# Altium schematic sheet CLOCK.SchDoc
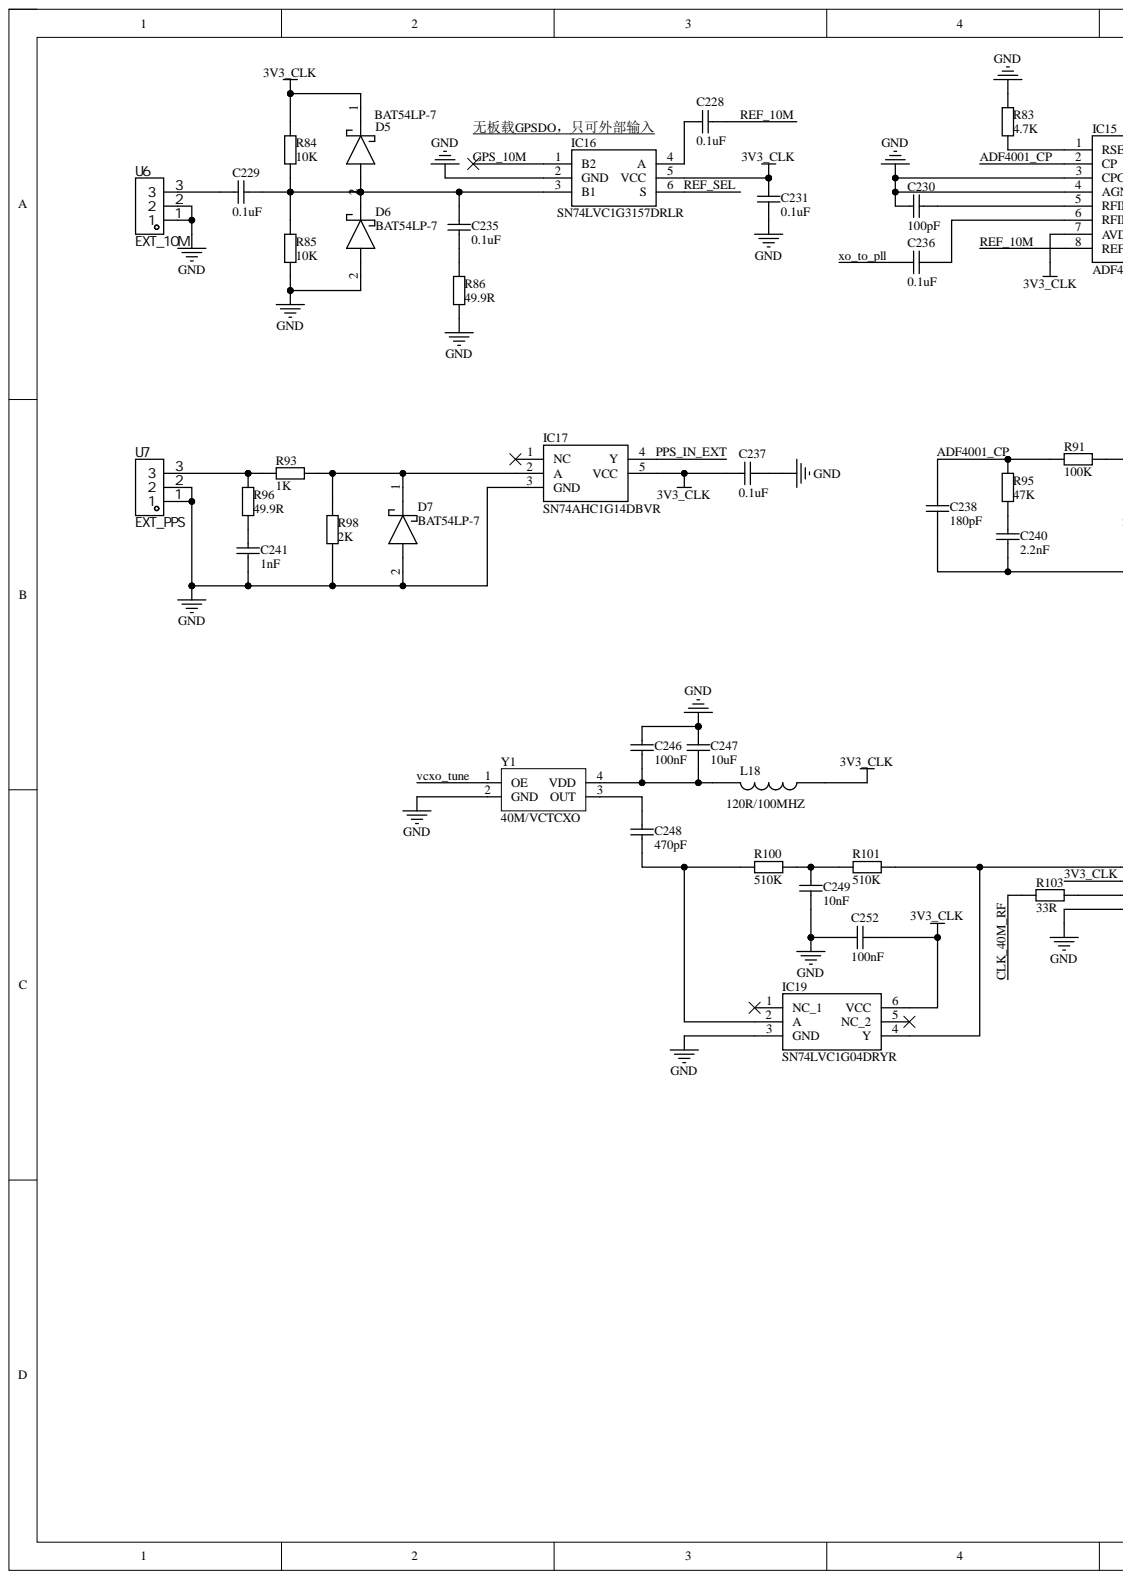
[diagram p0: CLOCK.SchDoc, left]
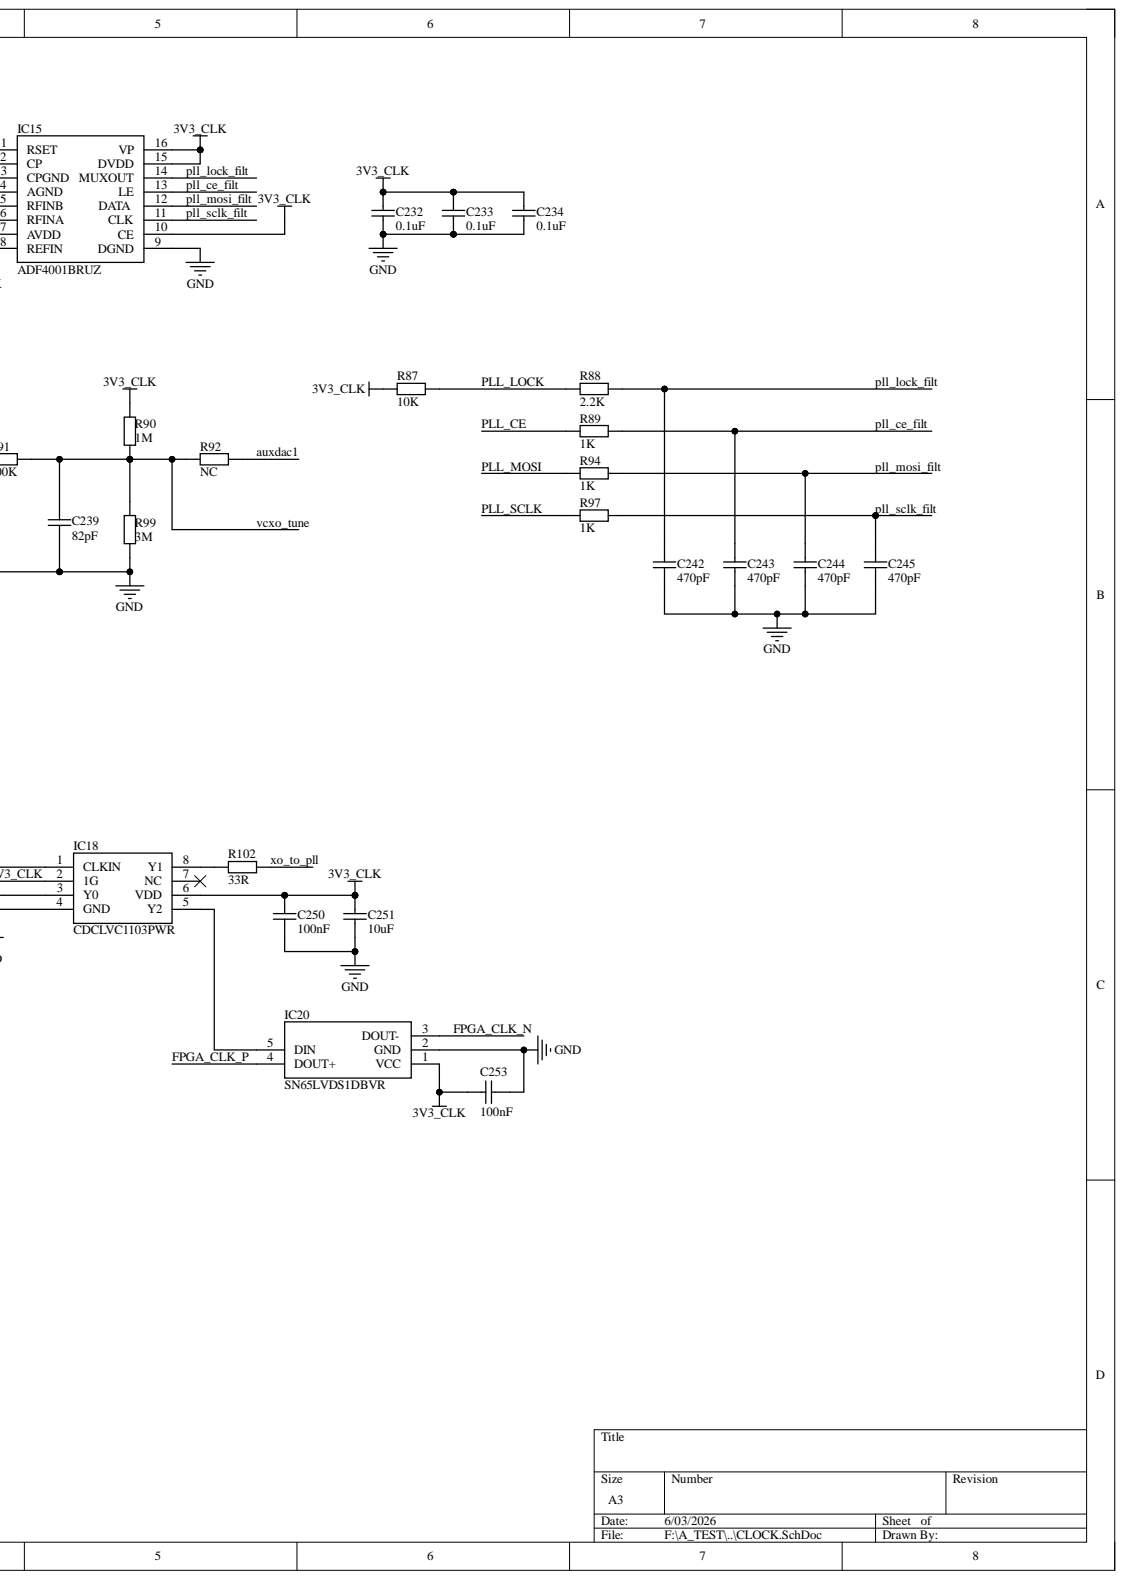
[diagram p1: CLOCK.SchDoc, right]

## Parts
C228  0.1uF  [C 0402_L]
C229  0.1uF  [C 0402_L]
C230  100pF  [C 0402_L]
C231  0.1uF  [C 0402_L]
C232  0.1uF  [C 0402_L]
C233  0.1uF  [C 0402_L]
C234  0.1uF  [C 0402_L]
C235  0.1uF  [C 0402_L]
C236  0.1uF  [C 0402_L]
C237  0.1uF  [C 0402_L]
C238  180pF  [C 0402_L]
C239  82pF  [C 0402_L]
C240  2.2nF  [C 0402_L]
C241  1nF  [C 0402_L]
C242  470pF  [C 0402_L]
C243  470pF  [C 0402_L]
C244  470pF  [C 0402_L]
C245  470pF  [C 0402_L]
C246  100nF  [C 0402_L]
C247  10uF  [C 0603_L]
C248  470pF  [C 0402_L]
C249  10nF  [C 0402_L]
C250  100nF  [C 0402_L]
C251  10uF  [C 0603_L]
C252  100nF  [C 0402_L]
C253  100nF  [C 0402_L]
D5  BAT54LP-7  [DESD3V3S1BL7B]
D6  BAT54LP-7  [DESD3V3S1BL7B]
D7  BAT54LP-7  [DESD3V3S1BL7B]
IC15  ADF4001BRUZ  [SOP65P640X120-16N]
IC16  SN74LVC1G3157DRLR  [SOTFL50P160X60-6N]
IC17  SN74AHC1G14DBVR  [SOT95P280X145-5N]
IC18  CDCLVC1103PWR  [SOP65P640X120-8N]
IC19  SN74LVC1G04DRYR  [TPS3420DDRYR]
IC20  SN65LVDS1DBVR  [SOT95P280X145-5N]
L18  120R/100MHZ  [L 0402]
R83  4.7K  [R 0402_L]
R84  10K  [R 0402_L]
R85  10K  [R 0402_L]
R86  49.9R  [R 0402_L]
R87  10K  [R 0402_L]
R88  2.2K  [R 0402_L]
R89  1K  [R 0402_L]
R90  1M  [R 0402_L]
R91  100K  [R 0402_L]
R92  NC  [R 0402_L]
R93  1K  [R 0402_L]
R94  1K  [R 0402_L]
R95  47K  [R 0402_L]
R96  49.9R  [R 0402_L]
R97  1K  [R 0402_L]
R98  2K  [R 0402_L]
R99  3M  [R 0402_L]
R100  510K  [R 0402_L]
R101  510K  [R 0402_L]
R102  33R  [R 0402_L]
R103  33R  [R 0402_L]
U6  EXT_10M  [SMA-SMD_73415-0963]
U7  EXT_PPS  [SMA-SMD_73415-0963]
Y1  40M/VCTCXO  [OSC 3225-4P]

## Nets
GND: C230.2 C231.1 C232.2 C233.2 C234.2 C237.2 C238.1 C239.2 C240.1 C241.1 C242.2 C243.2 C244.2 C245.2 C246.2 C247.2 C249.2 C250.1 C251.1 C252.1 C253.1 D6.2 D7.2 IC15.3(CPGND) IC15.4(AGND) IC15.9(DGND) IC16.2(GND) IC17.3(GND) IC18.4(GND) IC19.3(GND) IC20.2(GND) R83.1 R85.1 R86.1 R98.2 R99.2 U6.1 U6.2 U7.1 U7.2 Y1.2(GND)
3V3_CLK: C231.2 C232.1 C233.1 C234.1 C237.1 C250.2 C251.2 C252.2 C253.2 D5.1 IC15.7(AVDD) IC15.10(CE) IC15.15(DVDD) IC15.16(VP) IC16.5(VCC) IC17.5(VCC) IC18.2(1G) IC18.6(VDD) IC19.6(VCC) IC20.1(VCC) L18.1 R84.2 R87.1 R90.1
ADF4001_CP: C238.2 IC15.2(CP) R91.1 R95.1
auxdac1: R92.2
CLK_40M_RF: R103.2
FPGA_CLK_N: IC20.3(DOUT-)
FPGA_CLK_P: IC20.4(DOUT+)
GPS_10M: IC16.1(B2)
PLL_CE: R89.2
pll_ce_filt: C243.1 IC15.13(LE) R89.1
PLL_LOCK: R87.2 R88.2
pll_lock_filt: C242.1 IC15.14(MUXOUT) R88.1
PLL_MOSI: R94.2
pll_mosi_filt: C244.1 IC15.12(DATA) R94.1
PLL_SCLK: R97.2
pll_sclk_filt: C245.1 IC15.11(CLK) R97.1
PPS_IN_EXT: IC17.4(Y)
REF_10M: C228.1 IC15.8(REFIN)
REF_SEL: IC16.6(S)
vcxo_tune: C239.1 R90.2 R91.2 R92.1 R99.1 Y1.1(OE)
xo_to_pll: C236.2 R102.2
NetC228_2: C228.2 IC16.4(A)
NetC229_1: C229.1 U6.3
NetC229_2: C229.2 C235.1 D5.2 D6.1 IC16.3(B1) R84.1 R85.2
NetC230_1: C230.1 IC15.5(RFINB)
NetC235_2: C235.2 R86.2
NetC236_1: C236.1 IC15.6(RFINA)
NetC240_2: C240.2 R95.2
NetC241_2: C241.2 R96.1
NetC246_1: C246.1 C247.1 L18.2 Y1.4(VDD)
NetC248_1: C248.1 Y1.3(OUT)
NetC248_2: C248.2 IC19.2(A) R100.1
NetC249_1: C249.1 R100.2 R101.1
NetD7_1: D7.1 IC17.2(A) R93.1 R98.1
NetIC15_1: IC15.1(RSET) R83.2
NetIC18_1: IC18.1(CLKIN) IC19.4(Y) R101.2
NetIC18_3: IC18.3(Y0) R103.1
NetIC18_5: IC18.5(Y2) IC20.5(DIN)
NetIC18_8: IC18.8(Y1) R102.1
NetR93_2: R93.2 R96.2 U7.3

Not connected: IC17 1(NC); IC18 7(NC); IC19 1(NC_1) 5(NC_2)

## Library data of the parts
C228-C253 = Cap: 贴片电容; Component Kind: Standard; Library Name: A_Schlib.SchLib
  C228 C229 C231-C237: value: 0.1uF
  C230: value: 100pF
  C238: value: 180pF
  C239: value: 82pF
  C240: value: 2.2nF
  C241: value: 1nF
  C242-C245 C248: value: 470pF
  C246 C250 C252 C253: value: 100nF
  C247 C251: value: 10uF
  C249: value: 10nF
D5-D7 = BAT54LP-7: Schottky Diode; Manufacturer_Name: Diodes Incorporated; Arrow Part Number: BAT54LP-7; Arrow Price/Stock: https://www.arrow.com/en/products/bat54lp-7/diodes-incorporated?utm_currency=USD&region=nac; Component Kind: Standard; Datasheet Link: https://www.diodes.com//assets/Datasheets/BAT54LP.pdf; Library Name: SamacSys.SchLib; Manufacturer_Part_Number: BAT54LP-7; Mouser Part Number: 621-BAT54LP-7; Mouser Price/Stock: https://www.mouser.co.uk/ProductDetail/Diodes-Incorporated/BAT54LP-7?qs=rGAXPo9uwV29av2jO%2FVbTg%3D%3D
IC15 = ADF4001BRUZ: Integrated Circuit; Manufacturer_Name: Analog Devices; Arrow Part Number: ADF4001BRUZ; Arrow Price/Stock: https://www.arrow.com/en/products/adf4001bruz/maxim-integrated?region=nac; Component Kind: Standard; Datasheet Link: http://www.analog.com/static/imported-files/data_sheets/ADF4001.pdf; Height: 1.2mm; Library Name: SamacSys.SchLib; Manufacturer_Part_Number: ADF4001BRUZ; Mouser Part Number: 584-ADF4001BRUZ; Mouser Price/Stock: https://www.mouser.co.uk/ProductDetail/Analog-Devices/ADF4001BRUZ?qs=BpaRKvA4VqFDGFvzhGXRyA%3D%3D
IC16 = SN74LVC1G3157DRLR: Integrated Circuit; Manufacturer_Name: Texas Instruments; Arrow Part Number: SN74LVC1G3157DRLR; Arrow Price/Stock: https://www.arrow.com/en/products/sn74lvc1g3157drlr/texas-instruments?utm_currency=USD&region=nac; Component Kind: Standard; Datasheet Link: http://www.ti.com/lit/gpn/sn74lvc1g3157; Height: 0.6mm; Library Name: SamacSys.SchLib; Manufacturer_Part_Number: SN74LVC1G3157DRLR; Mouser Part Number: 595-SN74LVC1G3157DRL; Mouser Price/Stock: https://www.mouser.co.uk/ProductDetail/Texas-Instruments/SN74LVC1G3157DRLR?qs=PVVDbbWpW3LmfSj83Os0NA%3D%3D
IC17 = SN74AHC1G14DBVR: Integrated Circuit; Manufacturer_Name: Texas Instruments; Arrow Part Number: SN74AHC1G14DBVR; Arrow Price/Stock: https://www.arrow.com/en/products/sn74ahc1g14dbvr/texas-instruments?utm_currency=USD&region=nac; Component Kind: Standard; Datasheet Link: http://www.ti.com/lit/ds/symlink/sn74ahc1g14.pdf; Height: 1.45mm; Library Name: SamacSys.SchLib; Manufacturer_Part_Number: SN74AHC1G14DBVR; Mouser Part Number: 595-SN74AHC1G14DBVR; Mouser Price/Stock: https://www.mouser.co.uk/ProductDetail/Texas-Instruments/SN74AHC1G14DBVR?qs=DXdcjUGny5PvLZ5IXggTJg%3D%3D
IC18 = CDCLVC1103PWR: Integrated Circuit; Manufacturer_Name: Texas Instruments; Arrow Part Number: CDCLVC1103PWR; Arrow Price/Stock: https://www.arrow.com/en/products/cdclvc1103pwr/texas-instruments?region=nac; Component Kind: Standard; Datasheet Link: http://www.ti.com/lit/gpn/cdclvc1103; Height: 1.2mm; Library Name: SamacSys.SchLib; Manufacturer_Part_Number: CDCLVC1103PWR; Mouser Part Number: 595-CDCLVC1103PWR; Mouser Price/Stock: https://www.mouser.co.uk/ProductDetail/Texas-Instruments/CDCLVC1103PWR?qs=CLImetaeaXV5gv3gJV8gTw%3D%3D
IC19 = SN74LVC1G04DRYR: Integrated Circuit; Manufacturer_Name: Texas Instruments; Arrow Part Number: SN74LVC1G04DRYR; Arrow Price/Stock: https://www.arrow.com/en/products/sn74lvc1g04dryr/texas-instruments?region=nac; Component Kind: Standard; Datasheet Link: http://www.ti.com/lit/gpn/sn74lvc1g04; Height: 0mm; Library Name: SamacSys.SchLib; Manufacturer_Part_Number: SN74LVC1G04DRYR; Mouser Part Number: 595-SN74LVC1G04DRYR; Mouser Price/Stock: https://www.mouser.co.uk/ProductDetail/Texas-Instruments/SN74LVC1G04DRYR?qs=7kv20MEVUlgyCI6MY8upNw%3D%3D
IC20 = FIN1001M5: Integrated Circuit; Manufacturer_Name: onsemi; Component Kind: Standard; Datasheet Link: https://www.mouser.sg/ProductDetail/onsemi-Fairchild/FIN1001M5?qs=JO9JUh%252Bewuk3rbYf4QPHFg%3D%3D&srsltid=AfmBOopaihQI_8RlGgHcEFwJNeD4olrvv-vCIZuf58o4MAQGgeG-LMuM; Height: 1.45mm; Library Name: SamacSys.SchLib; Manufacturer_Part_Number: FIN1001M5
L18 = 电感: 包含功率; Component Kind: Standard; Library Name: A_Schlib.SchLib
R83-R103 = Res: 通用; Component Kind: Standard; Library Name: A_Schlib.SchLib
  R83: value: 4.7K
  R84 R85 R87: value: 10K
  R86 R96: value: 49.9R
  R88: value: 2.2K
  R89 R93 R94 R97: value: 1K
  R90: value: 1M
  R91: value: 100K
  R92: value: NC
  R95: value: 47K
  R98: value: 2K
  R99: value: 3M
  R100 R101: value: 510K
  R102 R103: value: 33R
U6 U7 = HJ-MMCX008: Manufacturer: 皇捷; Add into BOM: yes; RF Series: MMCX; Component Kind: Standard; Convert to PCB: yes; Datasheet: https://atta.szlcsc.com/upload/public/pdf/source/20230417/A13D8D93BC4451C7904403BCE88E907A.pdf; Device: HJ-MMCX008; JLCPCB Part Class: 扩展库; LCSC Part Name: MMCX; Library Name: A_Schlib.SchLib; Manufacturer Part: HJ-MMCX008; PCB3D: SMA-SMD_73415-0963; Supplier: LCSC; Supplier Footprint: -; Supplier Part: C5451762; Symbol: HJ-MMCX008
Y1 = XATLS-4P: 4脚贴片有源晶振; Component Kind: Standard; Library Name: A_Schlib.SchLib; Value: 40M/VCTCXO; 封装库来自淘宝卖家 ID：黄晶宝石: https://shop463601444.taobao.com
